# Altium schematic sheet drvoutput5.SchDoc(drvoutput5)
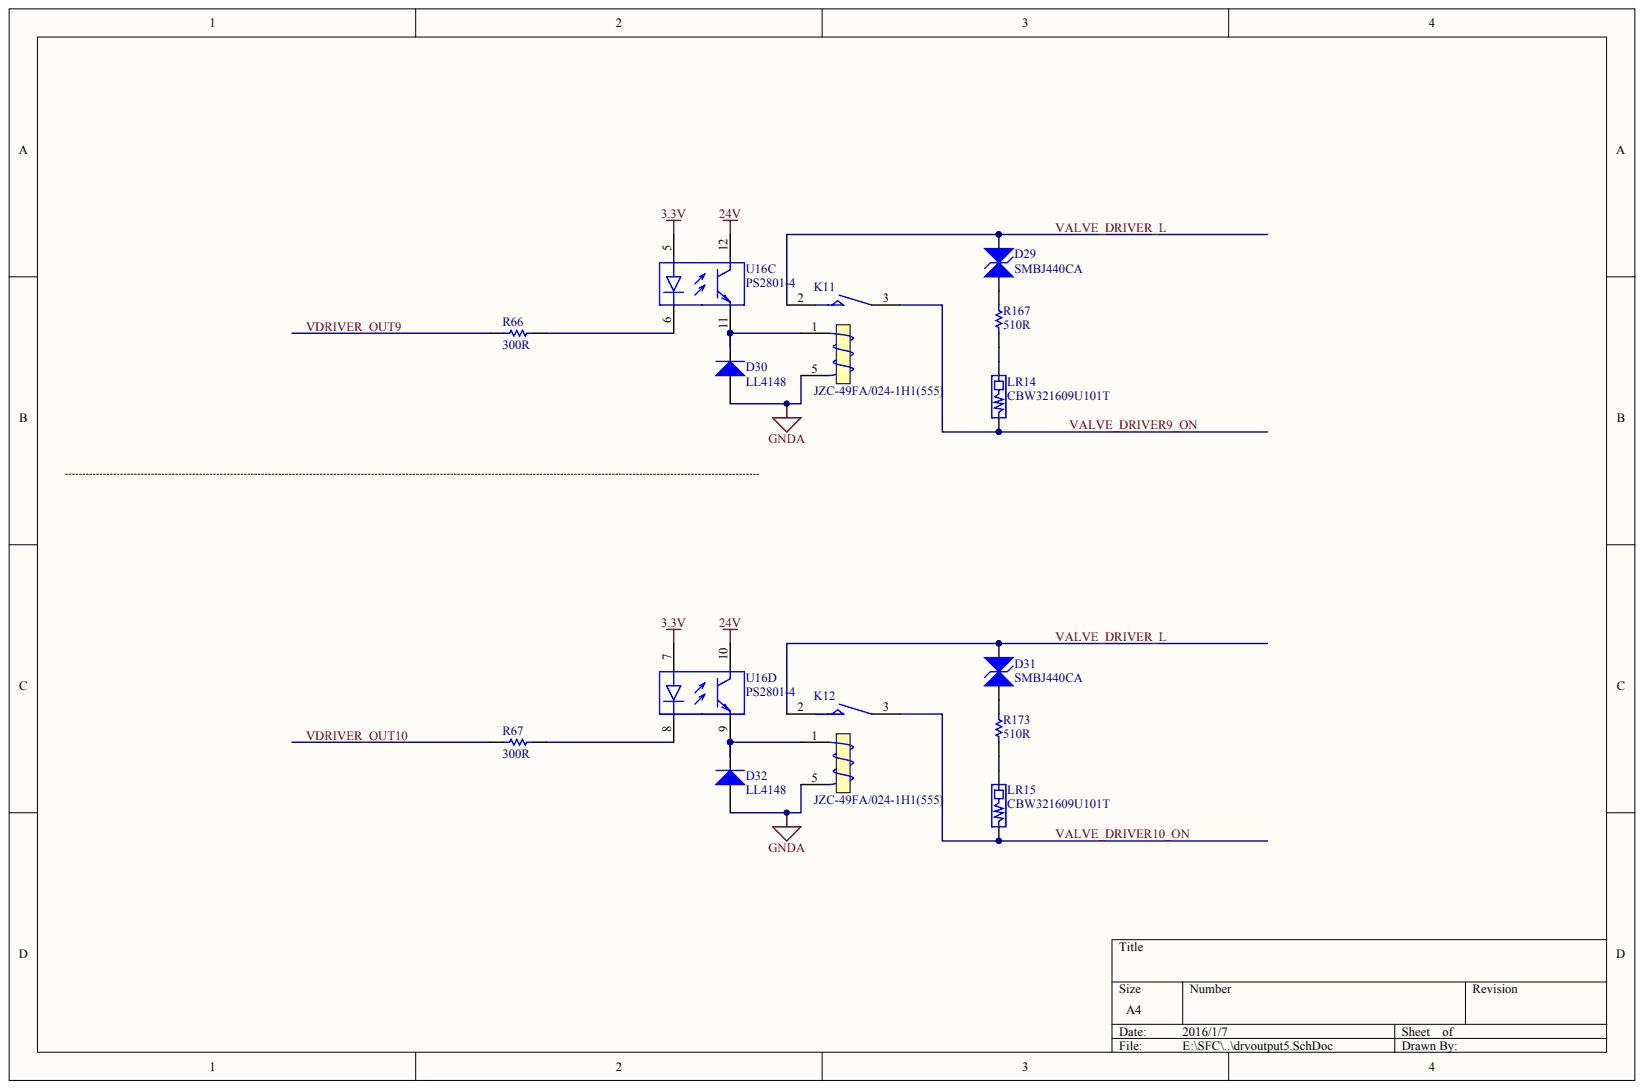
[diagram p0: drvoutput5.SchDoc]

## Parts
D29  SMBJ440CA
D30  LL4148
D31  SMBJ440CA
D32  LL4148
K11  JZC-49FA/024-1H1(555)
K12  JZC-49FA/024-1H1(555)
LR14  CBW321609U101T
LR15  CBW321609U101T
R66  300R
R67  300R
R167  510R
R173  510R
U16  PS2801-4  (parts C,D)

## Nets
3.3V: U16.5 U16.7
24V: U16.10 U16.12
GNDA: D30.1 D32.1 K11.5 K12.5
VALVE_DRIVER9_ON: K11.3 LR14.1
VALVE_DRIVER10_ON: K12.3 LR15.1
VALVE_DRIVER_L: D29.2 D31.2 K11.2 K12.2
VDRIVER_OUT9: R66.1
VDRIVER_OUT10: R67.1
NetD29_1: D29.1 R167.2
NetD30_2: D30.2 K11.1 U16.11
NetD31_1: D31.1 R173.2
NetD32_2: D32.2 K12.1 U16.9
NetLR14_2: LR14.2 R167.1
NetLR15_2: LR15.2 R173.1
NetR66_2: R66.2 U16.6
NetR67_2: R67.2 U16.8

## Library data of the parts
R66 R67 R167 R173 = (no symbol name): Value: 10K; Published: 8-Jun-2000; LatestRevisionDate: 17-Jul-2002; LatestRevisionNote: Re-released for DXP Platform.; PackageReference: AXIAL-0.3; Publisher: Altium Limited
D29-D32 K11 K12 LR14 LR15 U16 = (no symbol name)
  D29 D31: Published: 8-Jun-2000; LatestRevisionDate: 17-Jul-2002; LatestRevisionNote: Re-released for DXP Platform.; PackageReference: DIODE-0.7
  D30 D32: Published: 8-Jun-2000; LatestRevisionDate: 17-Jul-2002; LatestRevisionNote: Re-released for DXP Platform.; DatasheetVersion: 1998; PackageVersion: Aug-1999; PackageReference: DO-41; Code_JEDEC: DO-204-AL; ComponentLink1Description: Manufacturer Link; ComponentLink1URL: http://www.fairchildsemi.com/; ComponentLink2Description: Datasheet; ComponentLink2URL: http://www.fairchildsemi.com/ds/1N%2F1N4001.pdf
  K11 K12: Published: 8-Jun-2000; LatestRevisionDate: 17-Jul-2002; LatestRevisionNote: Re-released for DXP Platform.; PackageReference: MODULE5B
  U16: Published: 28-Feb-2003; LatestRevisionDate: 13-Apr-2006; LatestRevisionNote: IPC-7351 Footprint Added.; DatasheetVersion: Jan-2002, Rev. 7; PackageVersion: rev7, Jan-2002; PackageReference: 751-07; PackageDescription: 8-Pin Plastic Small Outline Integrated Circuit 1.27 mm Pitch; Code_JEDEC: MS-012-AA; Code_IPC: SOIC127P600-8; ComponentLink1Description: Manufacturer Link; ComponentLink1URL: http://www.onsemi.com/; ComponentLink2Description: Datasheet; ComponentLink2URL: http://www.onsemi.com/pub/Collateral/LM358-D.PDF
  D29-D32 K11 K12 U16: Publisher: Altium Limited
